# Altium schematic sheet Sheet3_IR.SchDoc
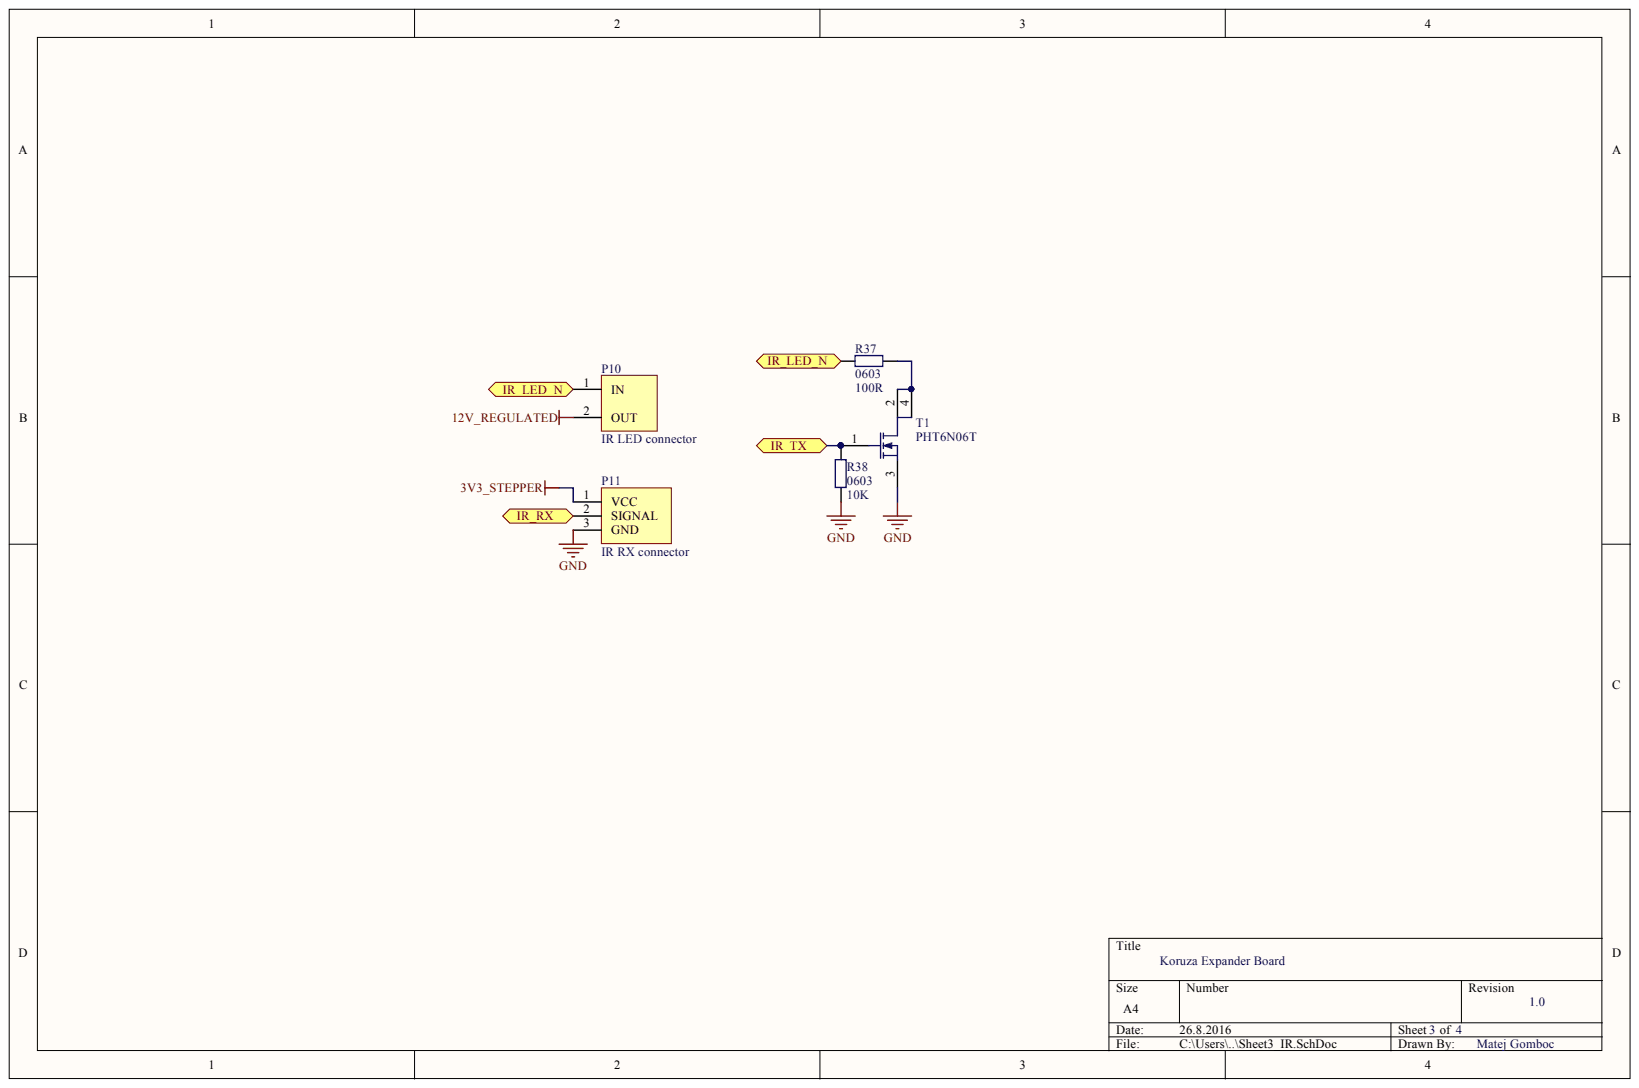
[diagram p0: Sheet3_IR.SchDoc]

## Parts
P10  IR LED connector  [term_block_2_45mm]
P11  IR RX connector  [term_block_3pole_2_45mm]
R37  0603  value 100R  [0603_HandSoldering]
R38  0603  value 10K  [0603_HandSoldering]
T1  PHT6N06T  [SOT230P700X180-4N]

## Ports
IR_LED_N, IR_RX, IR_TX

## Nets
3V3_STEPPER: P11.1
12V_REGULATED: P10.2
GND: P11.3 R38.1 T1.3
IR_LED_N: P10.1 R37.2 ; port IR_LED_N
IR_RX: P11.2 ; port IR_RX
IR_TX: R38.2 T1.1 ; port IR_TX
NetR37_1: R37.1 T1.2 T1.4

## Library data of the parts
P10 = IR_LED_connector: Component Kind: Standard; Description: IR LED connector; Library Name: SchlibKoruzaExpanderBoard.SchLib
P11 = IR_RX_connector: Component Kind: Standard; Description: IR RX connector; Library Name: SchlibKoruzaExpanderBoard.SchLib
R37 = resistor: Value: 100R; Component Kind: Standard; Description: Resistor; Library Name: SchlibKoruzaExpanderBoard.SchLib
R38 = resistor: Component Kind: Standard; Description: Resistor; Library Name: SchlibKoruzaExpanderBoard.SchLib
T1 = PHT6N06T: N MOSFET; Component Kind: Standard; Library Name: SchlibKoruzaExpanderBoard.SchLib
